# Altium schematic sheet Connectors.SchDoc
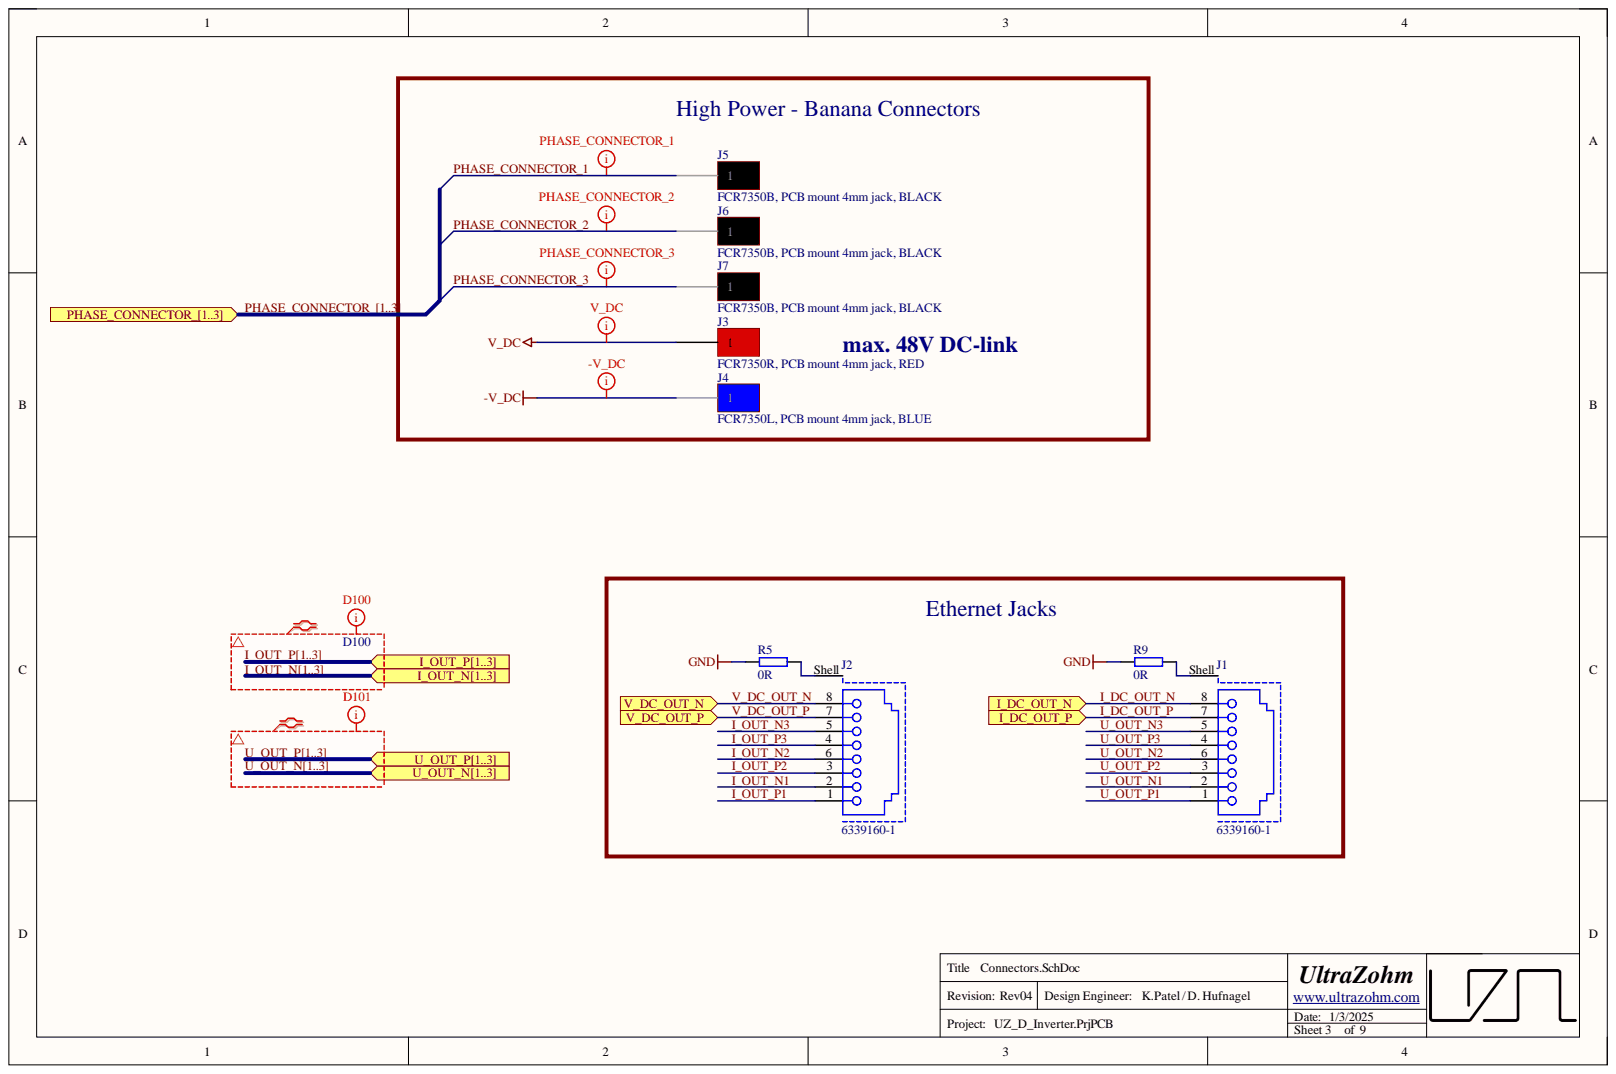
[diagram p0: Connectors.SchDoc]

## Parts
J1  6339160-1  [634008149821]
J2  6339160-1  [634008149821]
J3  FCR7350R, PCB mount 4mm jack, RED  [FCR7350]
J4  FCR7350L, PCB mount 4mm jack, BLUE  [FCR7350]
J5  FCR7350B, PCB mount 4mm jack, BLACK  [FCR7350]
J6  FCR7350B, PCB mount 4mm jack, BLACK  [FCR7350]
J7  FCR7350B, PCB mount 4mm jack, BLACK  [FCR7350]
R5  0R  [RES 0603_1608]
R9  0R  [RES 0603_1608]

## Ports
I_DC_OUT_N, I_DC_OUT_P, I_OUT_N1, I_OUT_N2, I_OUT_N3, I_OUT_N[1..3], I_OUT_P1, I_OUT_P2, I_OUT_P3, I_OUT_P[1..3], PHASE_CONNECTOR_1, PHASE_CONNECTOR_2, PHASE_CONNECTOR_3, PHASE_CONNECTOR_[1..3], U_OUT_N1, U_OUT_N2, U_OUT_N3, U_OUT_N[1..3], U_OUT_P1, U_OUT_P2, U_OUT_P3, U_OUT_P[1..3], V_DC_OUT_N, V_DC_OUT_P

## Nets
-V_DC: J4.1
GND: R5.1 R9.1
V_DC: J3.1
I_DC_OUT_N: J1.8 ; port I_DC_OUT_N
I_DC_OUT_P: J1.7 ; port I_DC_OUT_P
I_OUT_N1: J2.2 ; port I_OUT_N[1..3]  [also labelled I_OUT_N]
I_OUT_N2: J2.6 ; port I_OUT_N[1..3]  [also labelled I_OUT_N]
I_OUT_N3: J2.5 ; port I_OUT_N[1..3]  [also labelled I_OUT_N]
I_OUT_P1: J2.1 ; port I_OUT_P[1..3]  [also labelled I_OUT_P]
I_OUT_P2: J2.3 ; port I_OUT_P[1..3]  [also labelled I_OUT_P]
I_OUT_P3: J2.4 ; port I_OUT_P[1..3]  [also labelled I_OUT_P]
PHASE_CONNECTOR_1: J5.1 ; port PHASE_CONNECTOR_[1..3]  [also labelled PHASE_CONNECTOR_]
PHASE_CONNECTOR_2: J6.1 ; port PHASE_CONNECTOR_[1..3]  [also labelled PHASE_CONNECTOR_]
PHASE_CONNECTOR_3: J7.1 ; port PHASE_CONNECTOR_[1..3]  [also labelled PHASE_CONNECTOR_]
U_OUT_N1: J1.2 ; port U_OUT_N[1..3]  [also labelled U_OUT_N]
U_OUT_N2: J1.6 ; port U_OUT_N[1..3]  [also labelled U_OUT_N]
U_OUT_N3: J1.5 ; port U_OUT_N[1..3]  [also labelled U_OUT_N]
U_OUT_P1: J1.1 ; port U_OUT_P[1..3]  [also labelled U_OUT_P]
U_OUT_P2: J1.3 ; port U_OUT_P[1..3]  [also labelled U_OUT_P]
U_OUT_P3: J1.4 ; port U_OUT_P[1..3]  [also labelled U_OUT_P]
V_DC_OUT_N: J2.8 ; port V_DC_OUT_N
V_DC_OUT_P: J2.7 ; port V_DC_OUT_P
NetJ1_Shell: J1.Shell R9.2
NetJ2_Shell: J2.Shell R5.2

## Library data of the parts
J1 J2 = 6339160-1: RJ45 RJReceptacle cat5 Gold Phosphor bronze Without LED -40℃~+85℃ SMD Ethernet Connectors/Modular Connectors (RJ45 RJ11) ROHS; Manufacturer: TE Connectivity; Contact Resistance: 20mOhm; Created from: NDiringer; Database Table Name: Interface - Ethernet; Insulation Resistance: 500MOhm; LED: No; Match Code: WR-MJ; Number of Ports: 1; Rated Current: 1.5A; Shielding: Yes; Withstanding Voltage: 1000VAC; Working Voltage: 120VAC; Category: Input/Output Connectors; Component Kind: Standard; Component Type: RJ45 Connector; ComponentLink1Description: Datasheet; ComponentLink1URL: https://jlcpcb.com/partdetail/TeConnectivity-63391601/C125076; ComponentLink2Description: Datasheet; ComponentLink2URL: https://www.we-online.com/redexpert/spec/634008149821?ad; Created On: 20.04.2023 12:29:11; Device Package: RJ45; Last Updated: 21.02.2024 15:07:56; LCSC Part Number: C125076; Library Name: user.DbLib; Live Cycle Status: 1; Manufacturer Part Number: 6339160-1; Mount: Surface Mount; Mounting Type: SMD; Number of Pins: 8P8C; Operating Temperature Max: 85°C; Operating Temperature Min: -40°C; Orientation: Horizontal; Packaging: Tray; PartID: 8; Series: RJHSE; Stock number: not stored; Supplier 1: LCSC; Supplier Part Number 1: C125076; Type: RJ45 Connector
J3 = FCR7350R: FCR7350B, 1000V, 24A, RED; Manufacturer: Cliff; Created from: DHufnagel; Database Table Name: Connectors - High Power; Value: PCB mount 4mm jack, Red FCR7350R, 1000V, 24A; Component Kind: Standard; Component Type: PCB mount 4mm jack, Red; ComponentLink1Description: datasheet; ComponentLink1URL: https://www.cliffuk.co.uk/products/testleads/sockets/S16NPC.pdf; ComponentLink2Description: drawing; ComponentLink2URL: https://media.digikey.com/pdf/Data%20Sheets/Cliff%20Electronic%20LTD%20PDF's/FCR7350_Drawing.pdf; Created On: 13.06.2022 10:18:11; Device Package: THT; Last Updated: 21.02.2024 15:19:13; LCSC Part Number: C7437326; Library Name: user.DbLib; Live Cycle Status: 1; Manufacturer Part Number: FCR7350R; Minimum Order: 1; Mounting Type: THT; Operating Temperature: -40°C - 80°C; PartID: 7; Series: S16N-PC; Stock number: not stored; Supplier 1: Digi-Key; Supplier 2: farnell; Supplier 3: LCSC; Supplier Part Number 1: 3185-FCR7350R-ND; Supplier Part Number 2: 1854508; Supplier Part Number 3: C7437326; Type: PCB mount 4mm jack, Red FCR7350R, 1000V, 24A
J4 = FCR7350L: FCR7350B, 1000V, 24A, BLUE; Manufacturer: Cliff; Created from: DHufnagel; Database Table Name: Connectors - High Power; Value: PCB mount 4mm jack, Blue FCR7350L, 1000V, 24A; Component Kind: Standard; Component Type: PCB mount 4mm jack, Blue; ComponentLink1Description: datasheet; ComponentLink1URL: https://www.cliffuk.co.uk/products/testleads/sockets/S16NPC.pdf; ComponentLink2Description: drawing; ComponentLink2URL: https://media.digikey.com/pdf/Data%20Sheets/Cliff%20Electronic%20LTD%20PDF's/FCR7350_Drawing.pdf; Created On: 13.06.2022 10:18:11; Device Package: THT; Last Updated: 28.06.2022 13:58:37; LCSC Part Number: C7190856; Library Name: user.DbLib; Live Cycle Status: 1; Manufacturer Part Number: FCR7350L; Minimum Order: 1; Mounting Type: THT; Operating Temperature: -40°C - 80°C; PartID: 8; Series: S16N-PC; Stock number: not stored; Supplier 1: Digi-Key; Supplier 2: farnell; Supplier Part Number 1: 3185-FCR7350L-ND; Supplier Part Number 2: 1854511; Type: PCB mount 4mm jack, Blue FCR7350L, 1000V, 24A
J5-J7 = FCR7350B: FCR7350B, 1000V, 24A, BLACK; Manufacturer: Cliff; Created from: DHufnagel; Database Table Name: Connectors - High Power; Value: PCB mount 4mm jack, Black	FCR7350B, 1000V, 24A; Component Kind: Standard; Component Type: PCB mount 4mm jack, Black; ComponentLink1Description: datasheet; ComponentLink1URL: https://www.cliffuk.co.uk/products/testleads/sockets/S16NPC.pdf; ComponentLink2Description: drawing; ComponentLink2URL: https://media.digikey.com/pdf/Data%20Sheets/Cliff%20Electronic%20LTD%20PDF's/FCR7350_Drawing.pdf; Created On: 13.06.2022 10:18:11; Device Package: THT; Last Updated: 21.02.2024 15:21:29; LCSC Part Number: C7437327; Library Name: user.DbLib; Live Cycle Status: 1; Manufacturer Part Number: FCR7350B; Minimum Order: 1; Mounting Type: THT; Operating Temperature: -40°C - 80°C; PartID: 6; Series: S16N-PC; Stock number: not stored; Supplier 1: Digi-Key; Supplier 2: farnell; Supplier 3: LCSC; Supplier Part Number 1: 3185-FCR7350B-ND; Supplier Part Number 2: 1854507; Supplier Part Number 3: C7437327; Type: PCB mount 4mm jack, Black	FCR7350B, 1000V, 24A
R5 R9 = RC0603JR-070RL: SMD resistor E24 series; Manufacturer: YAGEO; Created from: MGremer; Database Table Name: Resistors - SMD; Tolerance: 5%; Component Kind: Standard; Component Type: SMD resistor; ComponentLink1Description: Datasheet; ComponentLink1URL: https://www.mouser.de/datasheet/2/447/PYu-RC_Group_51_RoHS_L_10-1664068.pdf; Created On: 14.12.2020 16:17:14; Device Package: 0603; Last Updated: 04.03.2021 09:09:42; LCSC Part Number: C21189; Library Name: user.DbLib; Live Cycle Status: 1; Manufacturer Part Number: RC0603JR-070RL; Mounting Type: SMD; PartID: 1; Stock number: Labor SMD Container; Supplier 1: Mouser; Supplier 2: LCSC; Supplier Part Number 1: 603-RC0603JR-070RL; Supplier Part Number 2: C21189; Type: Resistor; Value: 0R
